# Altium schematic sheet Page2_power.SchDoc(Page2_power)
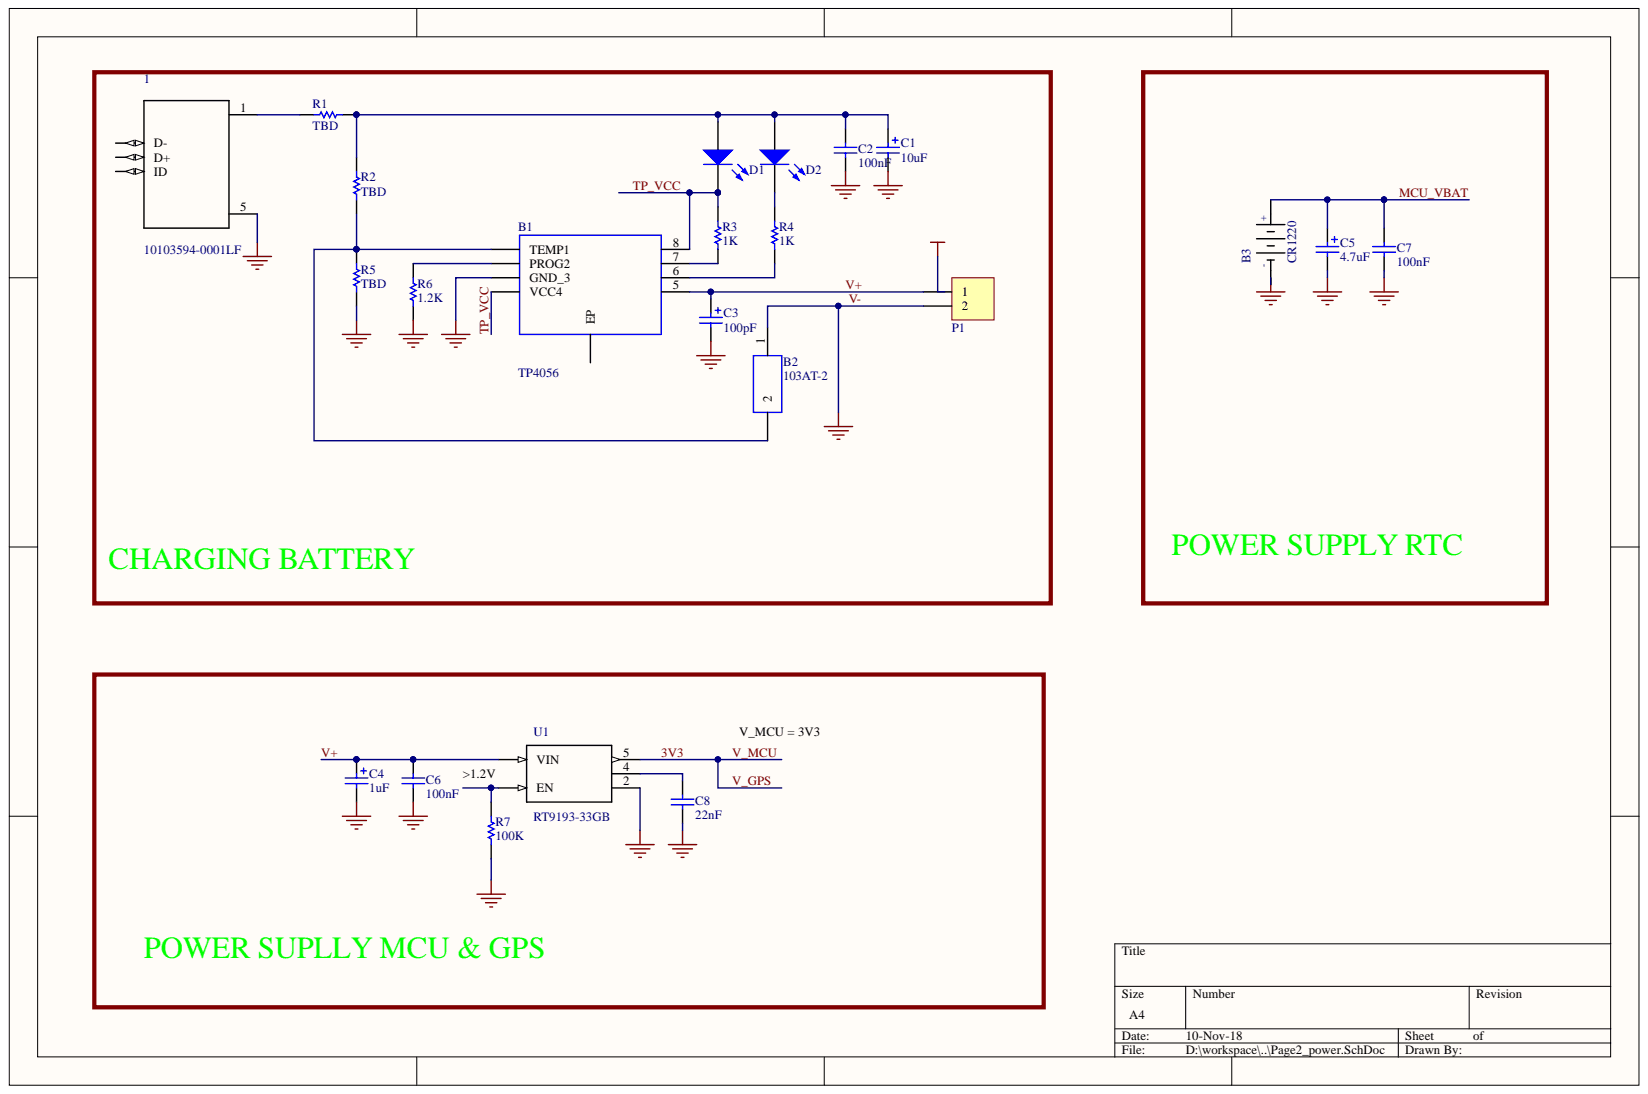
[diagram p0: Page2_power.SchDoc]

## Parts
1  10103594-0001LF  [POS-5]
B1  TP4056  [SOP-8]
B2  103AT-2  [103AT2]
B3  CR1220  [CR1220_CR1220(Primary)]
C1  value 10uF  [CAP ALU SIZE B]  (hidden comment: Cap Pol SMD)
C2  value 100nF  [CAP-SM-0603]  (hidden comment: Cap Non-Pol SMD)
C3  value 100pF  [CAP ALU SIZE B]  (hidden comment: Cap Pol SMD)
C4  value 1uF  [CAP ALU SIZE B]  (hidden comment: Cap Pol SMD)
C5  value 4.7uF  [CAP ALU SIZE B]  (hidden comment: Cap Pol SMD)
C6  value 100nF  [CAP-SM-0603]  (hidden comment: Cap Non-Pol SMD)
C7  value 100nF  [CAP-SM-0603]  (hidden comment: Cap Non-Pol SMD)
C8  value 22nF  [CAP-SM-0603]  (hidden comment: Cap Non-Pol SMD)
D1  LED SMD  [LED_0603_Blue]  (value not drawn)
D2  LED SMD  [LED_0603_Blue]  (value not drawn)
P1  Header 2  [JP2]  (value not drawn)
R1  value TBD  [RES-SM-0603]  (hidden comment: RESISTOR SMD)
R2  value TBD  [RES-SM-0603]  (hidden comment: RESISTOR SMD)
R3  value 1K  [RES-SM-0603]  (hidden comment: RESISTOR SMD)
R4  value 1K  [RES-SM-0603]  (hidden comment: RESISTOR SMD)
R5  value TBD  [RES-SM-0603]  (hidden comment: RESISTOR SMD)
R6  value 1.2K  [RES-SM-0603]  (hidden comment: RESISTOR SMD)
R7  value 100K  [RES-SM-0603]  (hidden comment: RESISTOR SMD)
U1  RT9193-33GB  [SOT94P279X129-5N]

## Nets
3V3: U1.5
MCU_VBAT: B3.POS C5.1 C7.2
TP_VCC: B1.4(VCC4) B1.8 D1.2 R3.2
V+: B1.5 C3.1 C4.1 C6.2 P1.1 U1.1(VIN)
V-: 1.5 B1.3(GND_3) B2.1 B3.NEG C1.2 C2.1 C3.2 C4.2 C5.2 C6.1 C7.1 C8.1 P1.2 R5.1 R6.1 R7.1 U1.2
Net1_1: 1.1 R1.2
NetB1_1: B1.1(TEMP1) B2.2 R2.1 R5.2
NetB1_2: B1.2(PROG2) R6.2
NetB1_6: B1.6 R4.1
NetB1_7: B1.7 R3.1
NetC1_1: C1.1 C2.2 D1.1 D2.1 R1.1 R2.2
NetC8_2: C8.2 U1.4
NetD2_2: D2.2 R4.2
NetR7_2: R7.2 U1.3(EN)
V_GSM:

Not connected: 1 2(D-) 3(D+) 4(ID); B1 9(EP)

## Library data of the parts
1 = 10103594-0001LF: Micro Usb, 2.0 Type b, Receptacle, Smt; MF: Amphenol FCI; SnapEDA_Link: https://www.snapeda.com/parts/10103594-0001LF/Amphenol%20FCI/view-part/?ref=snap; Price: None; Package: None; MP: 10103594-0001LF; Library Name: 10103594-0001LF.SchLib; Component Kind: Standard; Availability: Unavailable
B1 = TP4056: 1A Standalone Linear Li-lon Battery Charger, SOP-8; Manufacturer_Name: NanJing Top Power; Geometry.Height: 1.75mm; Manufacturer_Part_Number: TP4056; Library Name: TP4056.SchLib; Datasheet Link: https://dlnmh9ip6v2uc.cloudfront.net/datasheets/Prototyping/TP4056.pdf; Component Kind: Standard; Arrow Part Number: TP4056
B2 = 103AT-2: NTC Thermistors 10kohm 1%; Manufacturer_Name: Semitec; Geometry.Height: 8mm; Manufacturer_Part_Number: 103AT-2; Library Name: 103AT-2.SchLib; Datasheet Link: http://www.semitec-usa.com/downloads/atthermistor.pdf; Component Kind: Standard; Arrow Part Number: 103AT-2
B3 = CR1220: MF: FDK America,; SnapEDA_Link: https://www.snapeda.com/parts/CR1220/FDK%20America%2C%20Inc.%2C%20a%20member%20of%20Fujitsu%20Group/view-part/?ref=snap; Price: None; Package: DIP-2 Murata; MP: CR1220; Library Name: CR1220.SchLib; Component Kind: Standard; Availability: Unavailable
C1 C3-C5 = Cap Pol SMD: Polarized Capacitor (Radial); Library Name: PIF_Library.IntLib; Component Kind: Standard
C2 C6-C8 = Cap Non-Pol SMD: Capacitor; Library Name: PIF_Library.IntLib; Component Kind: Standard
D1 D2 = LED SMD: LED; Library Name: PIF_Library.IntLib; Component Kind: Standard
P1 = Header 2: Header, 2-Pin; Library Name: PIF_Library.IntLib; LatestRevisionNote: Re-released for DXP Platform.; LatestRevisionDate: 17-Jul-2002; Component Kind: Standard; Publisher: Altium Limited
R1-R7 = RESISTOR SMD: Resistor; Library Name: PIF_Library.IntLib; Component Kind: Standard
U1 = RT9193-33GB: RT9193 Series 300 mA 3.3 V Ultra-Low Noise Ultra-Fast CMOS LDO Regulator-SC-70-5; MF: Richtek USA; SnapEDA_Link: https://www.snapeda.com/parts/RT9193-33GB/Richtek%20USA%20Inc./view-part/?ref=snap; Price: None; Package: SOT-23-5 Richtek; MP: RT9193-33GB; Library Name: RT9193-33GB.SchLib; Component Kind: Standard; Availability: Unavailable
